# Altium schematic sheet FPGA.SchDoc
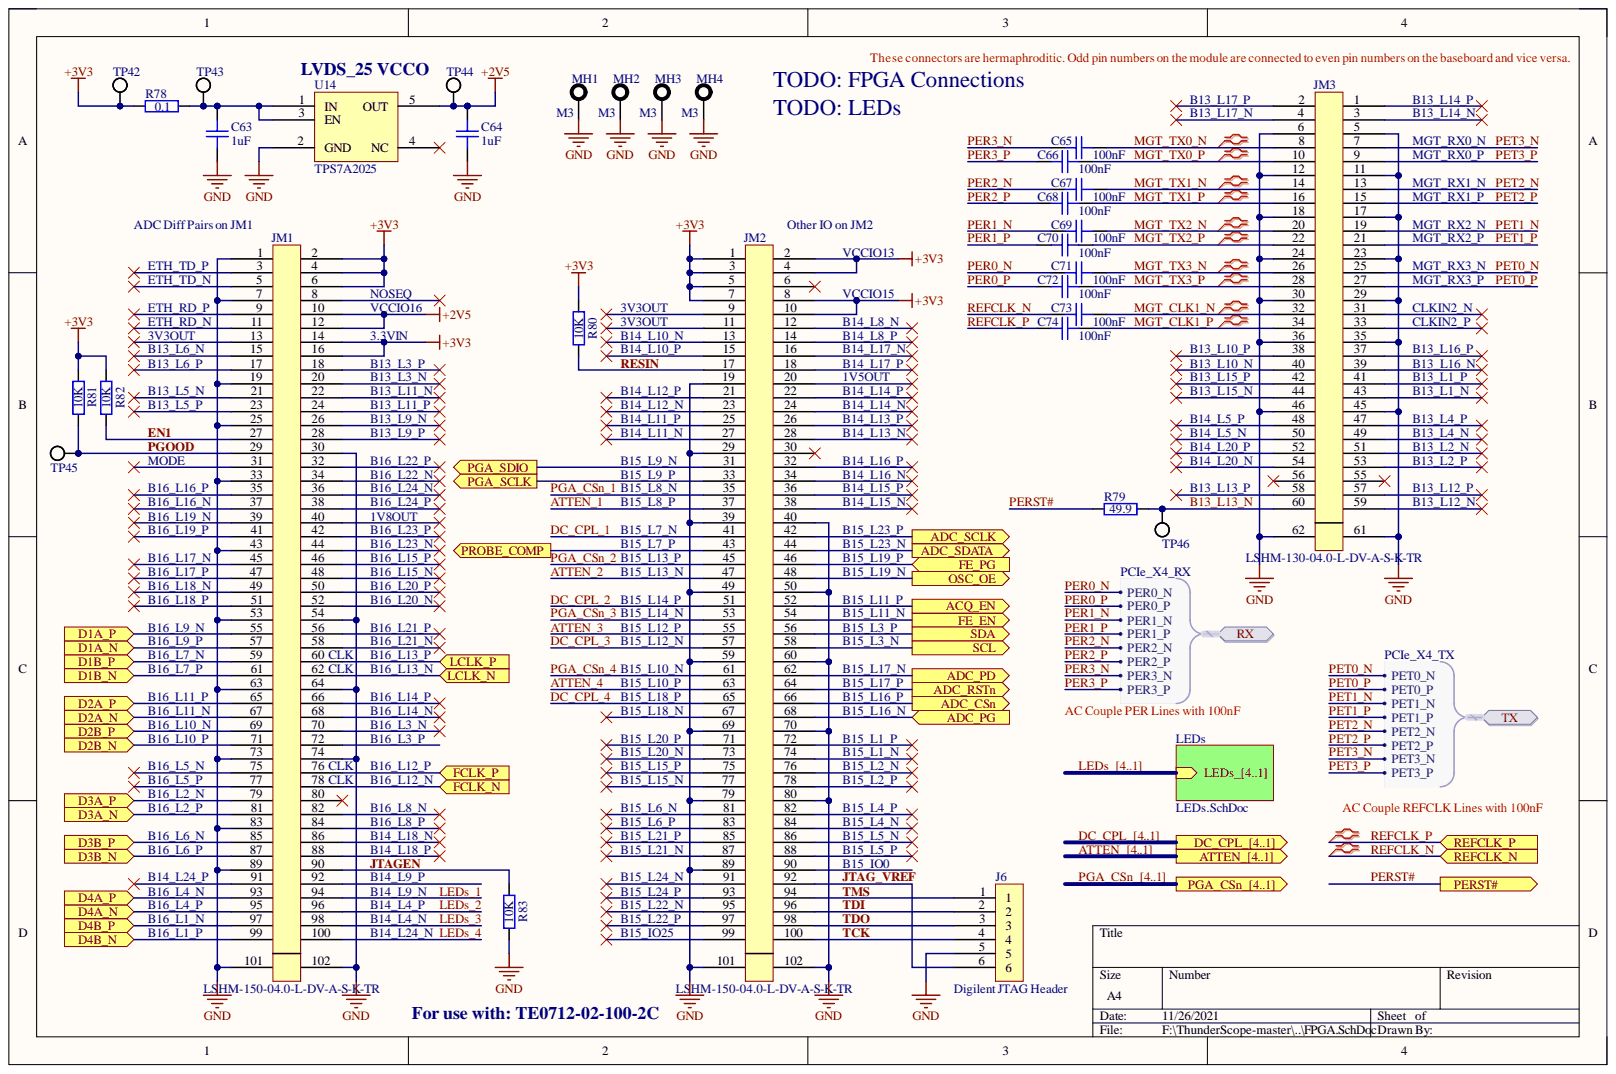
[diagram p0: FPGA.SchDoc]

## Parts
C63  1uF  [GEN_C_0402]
C64  1uF  [GEN_C_0402]
C65  100nF  [GEN_C_0402]
C66  100nF  [GEN_C_0402]
C67  100nF  [GEN_C_0402]
C68  100nF  [GEN_C_0402]
C69  100nF  [GEN_C_0402]
C70  100nF  [GEN_C_0402]
C71  100nF  [GEN_C_0402]
C72  100nF  [GEN_C_0402]
C73  100nF  [GEN_C_0402]
C74  100nF  [GEN_C_0402]
J6  2.54mm 6 Pin TH  [GEN_2.54mm_6_Pin_TH_RA]  (value not drawn)
JM1  LSHM-150-04.0-L-DV-A-S-K-TR  [SAM_LSHM-150-XX.X-XX-DV-A-S]
JM2  LSHM-150-04.0-L-DV-A-S-K-TR  [SAM_LSHM-150-XX.X-XX-DV-A-S]
JM3  LSHM-130-04.0-L-DV-A-S-K-TR  [SAM_LSHM-130-XX.X-XX-DV-A-S]
MH1  M3  [MH M3]  (no BOM)
MH2  M3  [MH M3]  (no BOM)
MH3  M3  [MH M3]  (no BOM)
MH4  M3  [MH M3]  (no BOM)
R78  0.1  [GEN_R_0402]
R79  49.9  [GEN_R_0402]
R80  10K  [GEN_R_0402]
R81  10K  [GEN_R_0402]
R82  10K  [GEN_R_0402]
R83  10K  [GEN_R_0402]
TP42  PCB  [GEN_TP_PCB]  (value not drawn)  (no BOM)
TP43  PCB  [GEN_TP_PCB]  (value not drawn)  (no BOM)
TP44  PCB  [GEN_TP_PCB]  (value not drawn)  (no BOM)
TP45  PCB  [GEN_TP_PCB]  (value not drawn)  (no BOM)
TP46  PCB  [GEN_TP_PCB]  (value not drawn)  (no BOM)
U14  TPS7A2025  [GEN_SOT23_5_950_2900X1600X1450]

## Ports
ACQ_EN, ADC_CSn, ADC_PD, ADC_PG, ADC_RSTn, ADC_SCLK, ADC_SDATA, ATTEN_1, ATTEN_2, ATTEN_3, ATTEN_4, ATTEN_[4..1], D1A_N, D1A_P, D1B_N, D1B_P, D2A_N, D2A_P, D2B_N, D2B_P, D3A_N, D3A_P, D3B_N, D3B_P, D4A_N, D4A_P, D4B_N, D4B_P, DC_CPL_1, DC_CPL_2, DC_CPL_3, DC_CPL_4, DC_CPL_[4..1], FCLK_N, FCLK_P, FE_EN, FE_PG, LCLK_N, LCLK_P, OSC_OE, PERST#, PGA_CSn_1, PGA_CSn_2, PGA_CSn_3, PGA_CSn_4, PGA_CSn_[4..1], PGA_SCLK, PGA_SDIO, PROBE_COMP, REFCLK_N, REFCLK_P, RX, RX.PER0_N, RX.PER0_P, RX.PER1_N, RX.PER1_P, RX.PER2_N, RX.PER2_P, RX.PER3_N, RX.PER3_P, SCL, SDA, TX, TX.PET0_N, TX.PET0_P, TX.PET1_N, TX.PET1_P, TX.PET2_N, TX.PET2_P, TX.PET3_N, TX.PET3_P

## Nets
+2V5: C64.2 JM1.10 JM1.12 TP44.1 U14.5(OUT)
+3V3: JM1.2 JM1.4 JM1.6 JM1.14 JM1.16 JM2.1 JM2.2 JM2.3 JM2.4 JM2.5 JM2.7 JM2.8 JM2.10 R78.1 R80.2 R81.2 R82.2 TP42.1
GND: C63.1 C64.1 J6.5 JM1.1 JM1.7 JM1.19 JM1.25 JM1.30 JM1.33 JM1.43 JM1.53 JM1.54 JM1.63 JM1.64 JM1.73 JM1.74 JM1.83 JM1.89 JM1.101 JM1.102 JM2.19 JM2.29 JM2.39 JM2.40 JM2.49 JM2.50 JM2.59 JM2.60 JM2.69 JM2.70 JM2.79 JM2.80 JM2.89 JM2.101 JM2.102 JM3.5 JM3.6 JM3.11 JM3.12 JM3.17 JM3.18 JM3.23 JM3.24 JM3.29 JM3.30 JM3.35 JM3.36 JM3.45 JM3.46 JM3.61 JM3.62 MH1.1 MH2.1 MH3.1 MH4.1 R83.1 U14.2(GND)
ACQ_EN: JM2.52 ; port ACQ_EN
ADC_CSn: JM2.66 ; port ADC_CSn
ADC_PD: JM2.62 ; port ADC_PD
ADC_PG: JM2.68 ; port ADC_PG
ADC_RSTn: JM2.64 ; port ADC_RSTn
ADC_SCLK: JM2.42 ; port ADC_SCLK
ADC_SDATA: JM2.44 ; port ADC_SDATA
ATTEN_1: JM2.37 ; port ATTEN_[4..1]  [also labelled ATTEN_]
ATTEN_2: JM2.47 ; port ATTEN_[4..1]  [also labelled ATTEN_]
ATTEN_3: JM2.55 ; port ATTEN_[4..1]  [also labelled ATTEN_]
ATTEN_4: JM2.63 ; port ATTEN_[4..1]  [also labelled ATTEN_]
B13_L13_N: JM3.60 R79.2 TP46.1
D1A_N: JM1.57 ; port D1A_N
D1A_P: JM1.55 ; port D1A_P
D1B_N: JM1.61 ; port D1B_N
D1B_P: JM1.59 ; port D1B_P
D2A_N: JM1.67 ; port D2A_N
D2A_P: JM1.65 ; port D2A_P
D2B_N: JM1.71 ; port D2B_N
D2B_P: JM1.69 ; port D2B_P
D3A_N: JM1.81 ; port D3A_N
D3A_P: JM1.79 ; port D3A_P
D3B_N: JM1.87 ; port D3B_N
D3B_P: JM1.85 ; port D3B_P
D4A_N: JM1.95 ; port D4A_N
D4A_P: JM1.93 ; port D4A_P
D4B_N: JM1.99 ; port D4B_N
D4B_P: JM1.97 ; port D4B_P
DC_CPL_1: JM2.41 ; port DC_CPL_[4..1]  [also labelled DC_CPL_]
DC_CPL_2: JM2.51 ; port DC_CPL_[4..1]  [also labelled DC_CPL_]
DC_CPL_3: JM2.57 ; port DC_CPL_[4..1]  [also labelled DC_CPL_]
DC_CPL_4: JM2.65 ; port DC_CPL_[4..1]  [also labelled DC_CPL_]
EN1: JM1.27 R82.1
FCLK_N: JM1.78 ; port FCLK_N
FCLK_P: JM1.76 ; port FCLK_P
FE_EN: JM2.54 ; port FE_EN
FE_PG: JM2.46 ; port FE_PG
JTAG_VREF: J6.6 JM2.92
JTAGEN: JM1.90 R83.2
LCLK_N: JM1.62 ; port LCLK_N
LCLK_P: JM1.60 ; port LCLK_P
LEDs_1: JM1.94  [also labelled LEDs_]
LEDs_2: JM1.96  [also labelled LEDs_]
LEDs_3: JM1.98  [also labelled LEDs_]
LEDs_4: JM1.100  [also labelled LEDs_]
MGT_CLK1_N: C73.1 JM3.32
MGT_CLK1_P: C74.1 JM3.34
MGT_TX0_N: C65.1 JM3.8
MGT_TX0_P: C66.1 JM3.10
MGT_TX1_N: C67.1 JM3.14
MGT_TX1_P: C68.1 JM3.16
MGT_TX2_N: C69.1 JM3.20
MGT_TX2_P: C70.1 JM3.22
MGT_TX3_N: C71.1 JM3.26
MGT_TX3_P: C72.1 JM3.28
OSC_OE: JM2.48 ; port OSC_OE
PER0_N: C71.2 ; port RX
PER0_P: C72.2 ; port RX
PER1_N: C69.2 ; port RX
PER1_P: C70.2 ; port RX
PER2_N: C67.2 ; port RX
PER2_P: C68.2 ; port RX
PER3_N: C65.2 ; port RX
PER3_P: C66.2 ; port RX
PERST#: R79.1 ; port PERST#
PET0_N: JM3.25 ; port TX
PET0_P: JM3.27 ; port TX
PET1_N: JM3.19 ; port TX
PET1_P: JM3.21 ; port TX
PET2_N: JM3.13 ; port TX
PET2_P: JM3.15 ; port TX
PET3_N: JM3.7 ; port TX
PET3_P: JM3.9 ; port TX
PGA_CSn_1: JM2.35 ; port PGA_CSn_[4..1]  [also labelled PGA_CSn_]
PGA_CSn_2: JM2.45 ; port PGA_CSn_[4..1]  [also labelled PGA_CSn_]
PGA_CSn_3: JM2.53 ; port PGA_CSn_[4..1]  [also labelled PGA_CSn_]
PGA_CSn_4: JM2.61 ; port PGA_CSn_[4..1]  [also labelled PGA_CSn_]
PGA_SCLK: JM2.33 ; port PGA_SCLK
PGA_SDIO: JM2.31 ; port PGA_SDIO
PGOOD: JM1.29 R81.1 TP45.1
PROBE_COMP: JM2.43 ; port PROBE_COMP
REFCLK_N: C73.2 ; port REFCLK_N
REFCLK_P: C74.2 ; port REFCLK_P
RESIN: JM2.17 R80.1
SCL: JM2.58 ; port SCL
SDA: JM2.56 ; port SDA
TCK: J6.4 JM2.100
TDI: J6.2 JM2.96
TDO: J6.3 JM2.98
TMS: J6.1 JM2.94
NetC63_2: C63.2 R78.2 TP43.1 U14.1(IN) U14.3(EN)

Not connected: JM1 3 5 8 9 11 13 15 17 18 20 21 22 23 24 26 28 31 32 34 35 36 37 38 39 40 41 42 44 45 46 47 48 49 50 51 52 56 58 66 68 70 72 75 77 80 82 84 86 88 91 92; JM2 6 9 11 12 13 14 15 16 18 20 21 22 23 24 25 26 27 28 30 32 34 36 38 67 71 72 73 74 75 76 77 78 81 82 83 84 85 86 87 88 90 91 93 95 97 99; JM3 1 2 3 4 31 33 37 38 39 40 41 42 43 44 47 48 49 50 51 52 53 54 55 56 57 58 59; U14 4(NC)

## Library data of the parts
C63 C64 = CAP 1uF 25V X5R 0402: Voltage Rating: 25V; Component Kind: Standard; Library Name: DSO.SchLib; Package: 0402; Supplier 1: Digi-Key; Supplier Part Number 1: 490-10017-1-ND; Value: 1uF
C65-C74 = CAP 100nF 16V X7R 0402: Voltage Rating: 16V; Component Kind: Standard; Library Name: DSO.SchLib; Package: 0402; Supplier 1: Digi-Key; Supplier Part Number 1: 490-4759-1-ND; Value: 100nF
J6 = CONN 2.54mm 6 Pin TH RA: Component Kind: Standard; Library Name: DSO.SchLib; Supplier 1: Digi-Key; Supplier Part Number 1: 732-5339-ND
JM1 JM2 = CONN LSHM-150-04.0-L-DV-A-S-K-TR: Component Kind: Standard; Library Name: DSO.SchLib; Supplier 1: Digi-Key; Supplier Part Number 1: SAM9033CT-ND
JM3 = CONN LSHM-130-04.0-L-DV-A-S-K-TR: Component Kind: Standard; Library Name: DSO.SchLib; Supplier 1: Digi-Key; Supplier Part Number 1: SAM9034CT-ND
MH1-MH4 = MH M3: Library Name: DSO.SchLib
R78 = RES 0.1 OHM 1% 1/6W 0402: Power Rating: 0.16W; Tolerance: 1%; Component Kind: Standard; Library Name: DSO.SchLib; Package: 0402; Supplier 1: Digi-Key; Supplier Part Number 1: P.10AKCT-ND; Value: 0.1
R79 = RES 49.9 OHM 1% 1/10W 0402: Power Rating: 0.1W; Tolerance: 1%; Component Kind: Standard; Library Name: DSO.SchLib; Package: 0402; Supplier 1: Digi-Key; Supplier Part Number 1: 311-49.9LRCT-ND; Value: 49.9
R80-R83 = RES 10K OHM 1% 1/10W 0402: Power Rating: 0.1W; Tolerance: 1%; Component Kind: Standard; Library Name: DSO.SchLib; Package: 0402; Supplier 1: Digi-Key; Supplier Part Number 1: P10.0KLCT-ND; Value: 10K
TP42-TP46 = TP PCB 1mm: Library Name: DSO.SchLib
U14 = IC LDO TPS7A2025: Component Kind: Standard; Library Name: DSO.SchLib; Supplier 1: Digi-Key; Supplier Part Number 1: 296-TPS7A2025PDBVRCT-ND
